# Altium schematic sheet connectors.SchDoc(connectors)
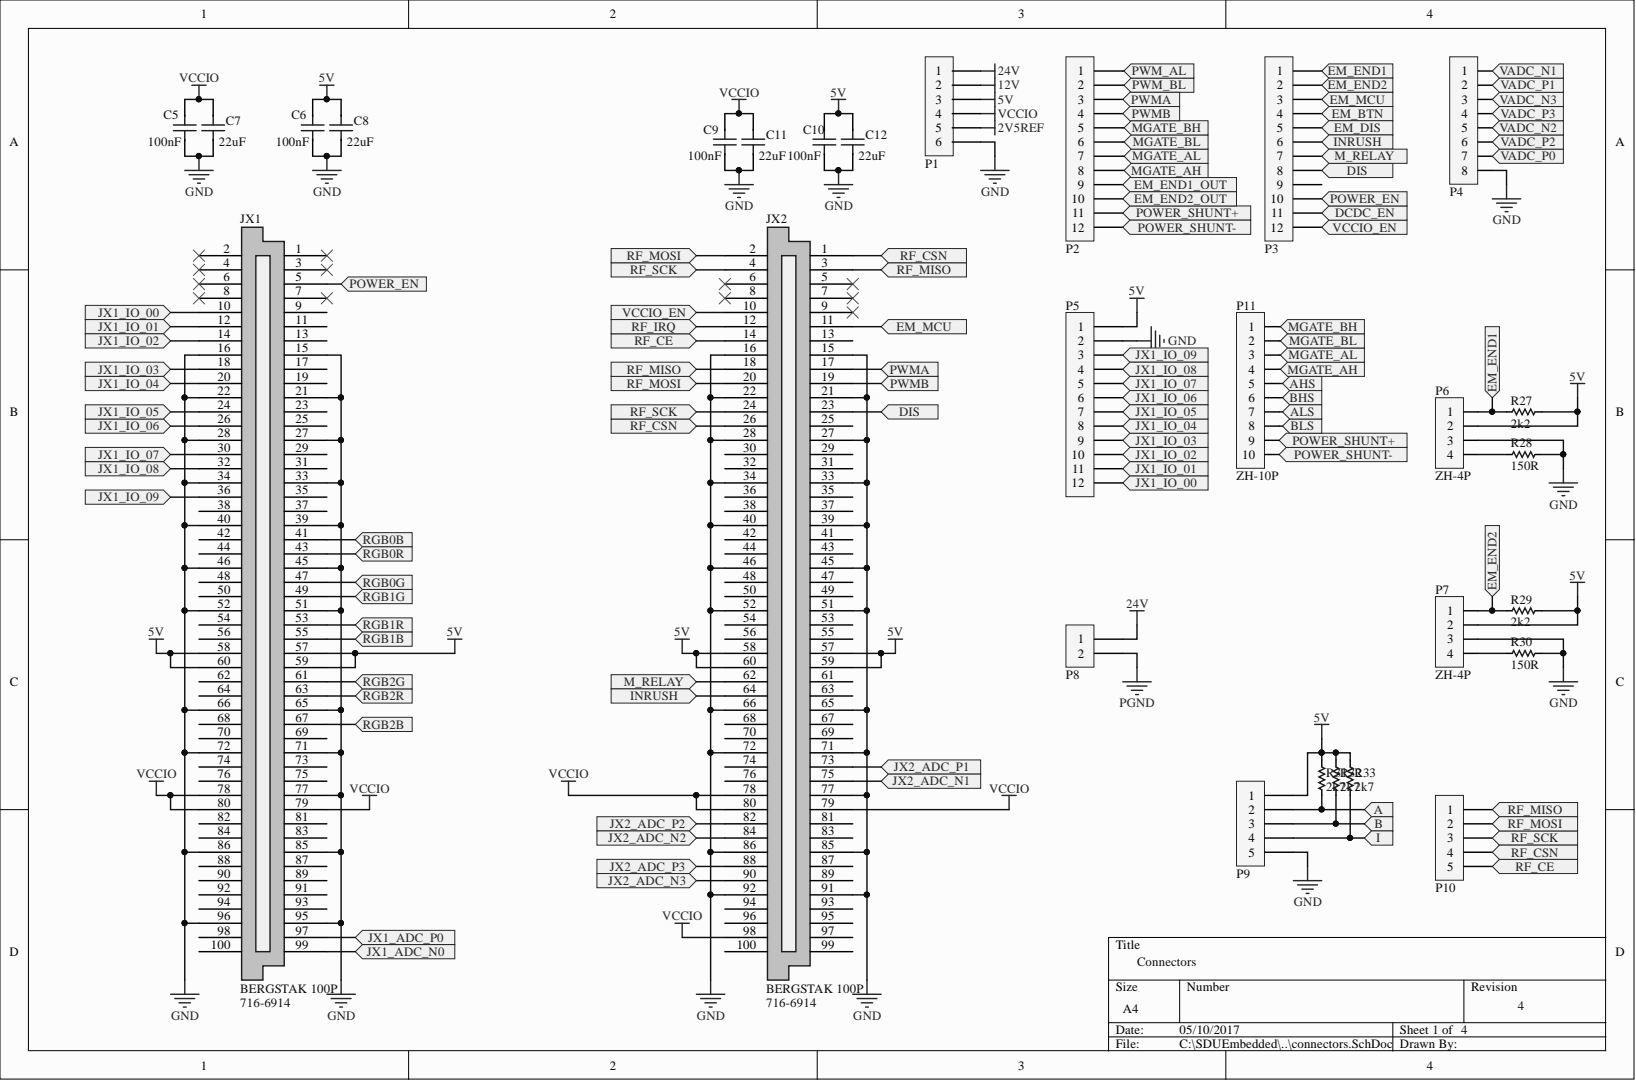
[diagram p0: connectors.SchDoc]

## Parts
C5  value 100nF  [CAP-0805]  (hidden comment: Capacitor)
C6  value 100nF  [CAP-0805]  (hidden comment: Capacitor)
C7  value 22uF  [CAP-0805]  (hidden comment: Capacitor)
C8  value 22uF  [CAP-0805]  (hidden comment: Capacitor)
C9  value 100nF  [CAP-0805]  (hidden comment: Capacitor)
C10  value 100nF  [CAP-0805]  (hidden comment: Capacitor)
C11  value 22uF  [CAP-0805]  (hidden comment: Capacitor)
C12  value 22uF  [CAP-0805]  (hidden comment: Capacitor)
JX1  BERGSTAK 100P  Supplier Part Number 1: 716-6914  [FCI_BERGSTAK_100P]
JX2  BERGSTAK 100P  Supplier Part Number 1: 716-6914  [FCI_BERGSTAK_100P]
P1  ZH-6P  [ZH-6P]  (value not drawn)
P2  ZH-12P  [ZH-12P]  (value not drawn)
P3  ZH-12P  [ZH-12P]  (value not drawn)
P4  ZH-8P  [ZH-8P]  (value not drawn)
P5  ZH-12P  [ZH-12P]  (value not drawn)
P6  ZH-4P  [ZH-4P]
P7  ZH-4P  [ZH-4P]
P8  2P Terminal  [2P-TERM]  (value not drawn)
P9  ZH-5P  [ZH-5P]  (value not drawn)
P10  ZH-5P  [ZH-5P]  (value not drawn)
P11  ZH-10P  [ZH-10P-T]
R27  value 2k2  [RES-0805]  (hidden comment: Resistor)
R28  value 150R  [RES-0805]  (hidden comment: Resistor)
R29  value 2k2  [RES-0805]  (hidden comment: Resistor)
R30  value 150R  [RES-0805]  (hidden comment: Resistor)
R31  value 2k7  [RES-0805]  (hidden comment: Resistor)
R32  value 2k7  [RES-0805]  (hidden comment: Resistor)
R33  value 2k7  [RES-0805]  (hidden comment: Resistor)

## Ports
A, AHS, ALS, B, BHS, BLS, DCDC_EN, DIS, EM_BTN, EM_DIS, EM_END1, EM_END1_OUT, EM_END2, EM_END2_OUT, EM_MCU, I, INRUSH, JX1_ADC_N0, JX1_ADC_P0, JX1_IO_00, JX1_IO_01, JX1_IO_02, JX1_IO_03, JX1_IO_04, JX1_IO_05, JX1_IO_06, JX1_IO_07, JX1_IO_08, JX1_IO_09, JX2_ADC_N1, JX2_ADC_N2, JX2_ADC_N3, JX2_ADC_P1, JX2_ADC_P2, JX2_ADC_P3, M_RELAY, MGATE_AH, MGATE_AL, MGATE_BH, MGATE_BL, POWER_EN, POWER_SHUNT+, POWER_SHUNT-, PWM_AL, PWM_BL, PWMA, PWMB, RF_CE, RF_CSN, RF_IRQ, RF_MISO, RF_MOSI, RF_SCK, RGB0B, RGB0G, RGB0R, RGB1B, RGB1G, RGB1R, RGB2B, RGB2G, RGB2R, VADC_N1, VADC_N2, VADC_N3, VADC_P0, VADC_P1, VADC_P2, VADC_P3, VCCIO_EN

## Nets
2V5REF: P1.5
5V: C6.2 C8.2 C10.2 C12.2 JX1.57 JX1.58 JX1.59 JX1.60 JX2.57 JX2.58 JX2.59 JX2.60 P1.3 P5.1 P6.2 P7.2 P9.1 R27.2 R29.2 R31.2 R32.2 R33.2
12V: P1.2
24V: P1.1 P8.1
GND: C5.1 C6.1 C7.1 C8.1 C9.1 C10.1 C11.1 C12.1 JX1.15 JX1.16 JX1.21 JX1.22 JX1.27 JX1.28 JX1.33 JX1.34 JX1.39 JX1.40 JX1.45 JX1.46 JX1.51 JX1.52 JX1.65 JX1.66 JX1.71 JX1.72 JX1.77 JX1.85 JX1.86 JX1.95 JX1.96 JX2.15 JX2.16 JX2.21 JX2.22 JX2.27 JX2.28 JX2.33 JX2.34 JX2.39 JX2.40 JX2.45 JX2.46 JX2.51 JX2.52 JX2.65 JX2.66 JX2.71 JX2.72 JX2.77 JX2.85 JX2.86 JX2.91 JX2.92 P1.6 P4.8 P5.2 P6.3 P7.3 P9.5 R28.2 R30.2
PGND: P8.2
VCCIO: C5.2 C7.2 C9.2 C11.2 JX1.78 JX1.79 JX1.80 JX2.78 JX2.79 JX2.80 JX2.98 P1.4
A: P9.2 R31.1 ; port A
AHS: P11.5 ; port AHS
ALS: P11.7 ; port ALS
B: P9.3 R32.1 ; port B
BHS: P11.6 ; port BHS
BLS: P11.8 ; port BLS
DCDC_EN: P3.11 ; port DCDC_EN
DIS: JX2.23 P3.8 ; port DIS
EM_BTN: P3.4 ; port EM_BTN
EM_DIS: P3.5 ; port EM_DIS
EM_END1: P3.1 P6.1 R27.1 ; port EM_END1
EM_END1_OUT: P2.9 ; port EM_END1_OUT
EM_END2: P3.2 P7.1 R29.1 ; port EM_END2
EM_END2_OUT: P2.10 ; port EM_END2_OUT
EM_MCU: JX2.11 P3.3 ; port EM_MCU
I: P9.4 R33.1 ; port I
INRUSH: JX2.64 P3.6 ; port INRUSH
JX1_ADC_N0: JX1.99 ; port JX1_ADC_N0
JX1_ADC_P0: JX1.97 ; port JX1_ADC_P0
JX1_IO_00: JX1.10 P5.12 ; port JX1_IO_00
JX1_IO_01: JX1.12 P5.11 ; port JX1_IO_01
JX1_IO_02: JX1.14 P5.10 ; port JX1_IO_02
JX1_IO_03: JX1.18 P5.9 ; port JX1_IO_03
JX1_IO_04: JX1.20 P5.8 ; port JX1_IO_04
JX1_IO_05: JX1.24 P5.7 ; port JX1_IO_05
JX1_IO_06: JX1.26 P5.6 ; port JX1_IO_06
JX1_IO_07: JX1.30 P5.5 ; port JX1_IO_07
JX1_IO_08: JX1.32 P5.4 ; port JX1_IO_08
JX1_IO_09: JX1.36 P5.3 ; port JX1_IO_09
JX2_ADC_N1: JX2.75 ; port JX2_ADC_N1
JX2_ADC_N2: JX2.84 ; port JX2_ADC_N2
JX2_ADC_N3: JX2.90 ; port JX2_ADC_N3
JX2_ADC_P1: JX2.73 ; port JX2_ADC_P1
JX2_ADC_P2: JX2.82 ; port JX2_ADC_P2
JX2_ADC_P3: JX2.88 ; port JX2_ADC_P3
M_RELAY: JX2.62 P3.7 ; port M_RELAY
MGATE_AH: P2.8 P11.4 ; port MGATE_AH
MGATE_AL: P2.7 P11.3 ; port MGATE_AL
MGATE_BH: P2.5 P11.1 ; port MGATE_BH
MGATE_BL: P2.6 P11.2 ; port MGATE_BL
POWER_EN: JX1.5 P3.10 ; port POWER_EN
PWM_AL: P2.1 ; port PWM_AL
PWM_BL: P2.2 ; port PWM_BL
PWMA: JX2.17 P2.3 ; port PWMA
PWMB: JX2.19 P2.4 ; port PWMB
RF_CE: JX2.14 P10.5 ; port RF_CE
RF_CSN: JX2.1 JX2.26 P10.4 ; port RF_CSN
RF_IRQ: JX2.12 ; port RF_IRQ
RF_MISO: JX2.3 JX2.18 P10.1 ; port RF_MISO
RF_MOSI: JX2.2 JX2.20 P10.2 ; port RF_MOSI
RF_SCK: JX2.4 JX2.24 P10.3 ; port RF_SCK
RGB0B: JX1.41 ; port RGB0B
RGB0G: JX1.47 ; port RGB0G
RGB0R: JX1.43 ; port RGB0R
RGB1B: JX1.55 ; port RGB1B
RGB1G: JX1.49 ; port RGB1G
RGB1R: JX1.53 ; port RGB1R
RGB2B: JX1.67 ; port RGB2B
RGB2G: JX1.61 ; port RGB2G
RGB2R: JX1.63 ; port RGB2R
VADC_N1: P4.1 ; port VADC_N1
VADC_N2: P4.5 ; port VADC_N2
VADC_N3: P4.3 ; port VADC_N3
VADC_P0: P4.7 ; port VADC_P0
VADC_P1: P4.2 ; port VADC_P1
VADC_P2: P4.6 ; port VADC_P2
VADC_P3: P4.4 ; port VADC_P3
VCCIO_EN: JX2.10 P3.12 ; port VCCIO_EN
NetP2_11: P2.11 P11.9
NetP2_12: P2.12 P11.10
NetP6_4: P6.4 R28.1
NetP7_4: P7.4 R30.1

Not connected: JX1 1 2 3 4 6 7 8 9 11 13 17 19 23 25 29 31 35 37 38 42 44 48 50 54 56 62 64 68 69 70 73 74 75 76 81 82 83 84 87 88 89 90 91 92 93 94 98 100; JX2 5 6 7 8 9 13 25 29 30 31 32 35 36 37 38 41 42 43 44 47 48 49 50 53 54 55 56 61 63 67 68 69 70 74 76 81 83 87 89 93 94 95 96 97 99 100; P3 9

## Library data of the parts
JX1 JX2 = BERGSTAK 100P: 100p connector, BERGSTAK; Supplier 1: RSComponents; Component Kind: Standard; Library Name: SDUEmbedded.SchLib
C5-C12 = Capacitor: Description: Capacitor; Component Kind: Standard; Library Name: SDUEmbedded.SchLib
P1 = ZH-6P: Component Kind: Standard; Library Name: SDUEmbedded.SchLib
P2 P3 P5 = ZH-12P: Component Kind: Standard; Library Name: SDUEmbedded.SchLib
P4 = ZH-8P: Component Kind: Standard; Library Name: SDUEmbedded.SchLib
P6 P7 = ZH-4P: Component Kind: Standard; Library Name: SDUEmbedded.SchLib
P8 = 2P Terminal: Supplier Part Number 1: 425-8720; Supplier 1: RSComponents; Component Kind: Standard; Library Name: SDUEmbedded.SchLib
P9 P10 = ZH-5P: Component Kind: Standard; Library Name: SDUEmbedded.SchLib
P11 = ZH-10P: Component Kind: Standard; Library Name: SDUEmbedded.SchLib
R27-R33 = Resistor: Description: Resistor; Component Kind: Standard; Library Name: SDUEmbedded.SchLib
